# Altium schematic sheet 04_LCD.SchDoc
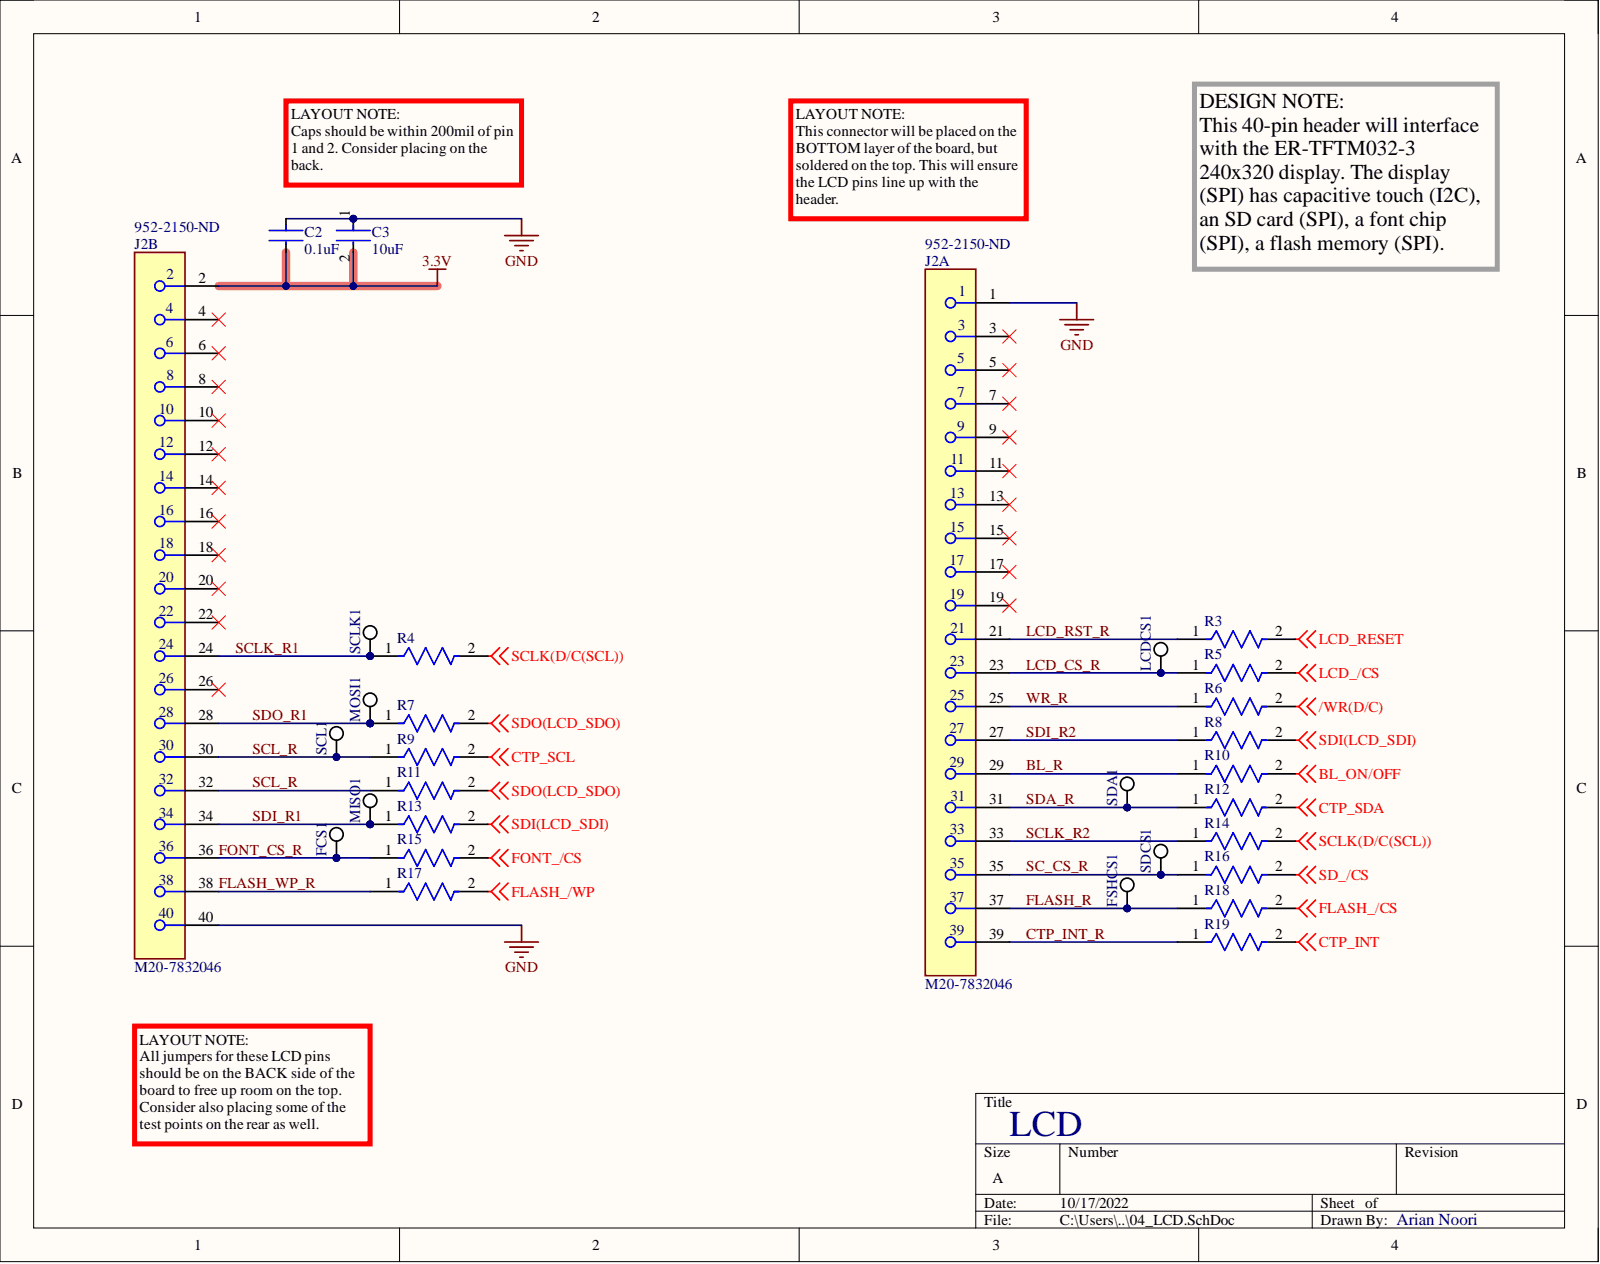
[diagram p0: 04_LCD.SchDoc]

## Parts
C2  0.1uF  [FP-CL21-IPC_C]
C3  value 10uF  Capacitance: 10uF  [FP-C0805C-DG-MFG]  (hidden comment: C0805C106K8PACTU)
FCS1  5019  [KSTN-5019_V]  (value not drawn)
FSHCS1  5019  [KSTN-5019_V]  (value not drawn)
J2  M20-7832046  Supplier Part Number 1: 952-2150-ND  [FP-M20-7832046-MFG]  (parts A,B)
LCDCS1  5019  [KSTN-5019_V]  (value not drawn)
MISO1  5019  [KSTN-5019_V]  (value not drawn)
MOSI1  5019  [KSTN-5019_V]  (value not drawn)
R3  (no value)  [FP-RC0805-MFG]
R4  (no value)  [FP-RC0805-MFG]
R5  (no value)  [FP-RC0805-MFG]
R6  (no value)  [FP-RC0805-MFG]
R7  (no value)  [FP-RC0805-MFG]
R8  (no value)  [FP-RC0805-MFG]
R9  (no value)  [FP-RC0805-MFG]
R10  (no value)  [FP-RC0805-MFG]
R11  (no value)  [FP-RC0805-MFG]
R12  (no value)  [FP-RC0805-MFG]
R13  (no value)  [FP-RC0805-MFG]
R14  (no value)  [FP-RC0805-MFG]
R15  (no value)  [FP-RC0805-MFG]
R16  (no value)  [FP-RC0805-MFG]
R17  (no value)  [FP-RC0805-MFG]
R18  (no value)  [FP-RC0805-MFG]
R19  (no value)  [FP-RC0805-MFG]
SCL1  5019  [KSTN-5019_V]  (value not drawn)
SCLK1  5019  [KSTN-5019_V]  (value not drawn)
SDA1  5019  [KSTN-5019_V]  (value not drawn)
SDCS1  5019  [KSTN-5019_V]  (value not drawn)

## Nets
3.3V: C2.2 C3.2 J2.2
/WR(D/C): R6.2
BL_ON/OFF: R10.2
CTP_INT: R19.2
CTP_SCL: R9.2
CTP_SDA: R12.2
FLASH_/CS: R18.2
FLASH_/WP: R17.2
FONT_/CS: R15.2
GND: C2.1 C3.1 J2.1 J2.40
LCD_/CS: R5.2
LCD_RESET: R3.2
SCLK(D/C(SCL)): R4.2 R14.2
SD_/CS: R16.2
SDI(LCD_SDI): R8.2 R13.2
SDO(LCD_SDO): R7.2 R11.2
BL_R: J2.29 R10.1
CTP_INT_R: J2.39 R19.1
FLASH_R: FSHCS1.1 J2.37 R18.1
FLASH_WP_R: J2.38 R17.1
FONT_CS_R: FCS1.1 J2.36 R15.1
LCD_CS_R: J2.23 LCDCS1.1 R5.1
LCD_RST_R: J2.21 R3.1
SC_CS_R: J2.35 R16.1 SDCS1.1
SCL_R: J2.30 J2.32 R9.1 R11.1 SCL1.1
SCLK_R1: J2.24 R4.1 SCLK1.1
SCLK_R2: J2.33 R14.1
SDA_R: J2.31 R12.1 SDA1.1
SDI_R1: J2.34 MISO1.1 R13.1
SDI_R2: J2.27 R8.1
SDO_R1: J2.28 MOSI1.1 R7.1
WR_R: J2.25 R6.1

Not connected: J2 3 4 5 6 7 8 9 10 11 12 13 14 15 16 17 18 19 20 22 26

## Library data of the parts
C2 = CMP-2000-05017-2: CL21 Series 0805 100nF 50V ±10% Tolerance X7R Multilayer Ceramic Chip Capacitor; Manufacturer: Samsung; Manufacturer Part Number: CL21B104KBCNNNC; Capacitance: 100nF; Case Code (Imperial): 0805; Case Code (Metric): 2012; Case/Package: 0805; Category: Ceramic Capacitors; Component Kind: Standard; ComponentLink1Description: https://datasheet.ciiva.com/25710/cl21b104kbcnnnc-25710182.pdf?src-supplier=Digi-Key; ComponentLink1URL: https://datasheet.ciiva.com/25710/cl21b104kbcnnnc-25710182.pdf?src-supplier=Digi-Key; ComponentLink2Description: https://datasheet.ciiva.com/29199/454152-da-01-en-vs-kondensator-0805-29199814.pdf?src-supplier=Conrad; ComponentLink2URL: https://datasheet.ciiva.com/29199/454152-da-01-en-vs-kondensator-0805-29199814.pdf?src-supplier=Conrad; Construction: Flat; Contact Plating: Tin; Depth: 1.25mm; Dielectric: X7R; Height: 0.85mm; Lead Free: Lead Free; Length: 2mm; Library Name: Altium Content Vault; Material: Ceramic; Max Operating Temperature: 125°C; Min Operating Temperature: -55°C; Mount: Surface Mount; Packaging: Tape and Reel; Pricing 1: 1=0.10000, 10=0.04400, 50=0.02400, 100=0.01960, 500=0.01404, 1000=0.01103 (USD); Radiation Hardening: No; RoHS: Yes; RoHS Compliant: Yes; Series: CL; Stock 1: 4599648; Supplier 1: Digi-Key; Thickness: 0.037inch; Tolerance: 10%; Voltage: 50V; Voltage Rating: 50V; Voltage Rating (DC): 50V; Width: 0.049inch
C3 = CMP-2007-03243-2: CAP CER 10UF 10V X5R 0805; 3DS Part Number: 220-00001; Manufacturer: Kemet; Dielectric Material: Ceramic; Dissipation Factor: 10%; Temperature Coefficient: 15%; Automotive: No; Case Code (Imperial): 0805; Case Code (Metric): 2012; Case/Package: 0805; Category: Cap; Component Kind: Standard; ComponentLink2Description: Datasheet; ComponentLink2URL: https://content.kemet.com/datasheets/KEM_C1006_X5R_SMD.pdf; Construction: Flat; Contact Plating: Tin,Matte; Depth: 1.25mm; Device Class L1: Passive Components; Device Class L2: Capacitors; Device Class L3: Ceramic Capacitors; Dielectric: X5R; Digikey Description: CAP CER 10UF 10V X5R 0805; Height: 1.4mm; Ipc Land Pattern Name: CAPC200125X125; Lead Free: Yes; Length: 2mm; Library Name: Altium Content Vault; Manufacturer 1: KEMET; Manufacturer Part Number: C0805C106K8PACTU; Manufacturer Part Number 1: C0805C106K8PACTU; Material: Ceramic; Max Operating Temperature: 85°C; Min Operating Temperature: -55°C; Mount: Surface Mount; Mouser Description: CAP CER 10UF 10V X5R 0805; Number of Pins: 2; Octopart: https://octopart.com/c0805c106k8pactu-kemet-98697; Package: C0805C-DG; Package Quantity: 1; Packaging: Tape and Reel; Pricing 1: 1=0.14000, 10=0.10300, 50=0.05840, 100=0.04830, 500=0.03388, 1000=0.02805 (USD); Radiation Hardening: No; REACH SVHC: No SVHC; RoHS: TRUE; RoHS Compliant: Yes; Series: C; Stock 1: 8631846; Supplier 1: Digi-Key; Supplier Part Number 1: 399-C0805C106K8PAC7800CT-ND; Temperature: -55°C to +85°C; Temperature Characteristic: X5R; Temperature Range High: +85°C; Temperature Range Low: -55°C; Termination: SMD/SMT; Thickness: 0.055inch; Tolerance: 10%; Voltage: 10V; Voltage Rating: 10V; Voltage Rating (DC): 10V; Width: 1.2mm
FCS1 FSHCS1 LCDCS1 MISO1 MOSI1 SCL1 SCLK1 SDA1 SDCS1 = CMP-1672-00008-1: Test Point, 1 Position SMD, RoHS, Tape and Reel; Manufacturer: Keystone Electronics; Category: Connectors; Component Kind: Standard; ComponentLink1Description: Manufacturer URL; ComponentLink1URL: http://www.keyelco.com/; ComponentLink2Description: Datasheet; ComponentLink2URL: http://www.keyelco.com/product-pdf.cfm?p=1357; ComponentLink3Description: https://datasheet.ciiva.com/16318/m60-2p61-16318395.pdf?src-supplier=Future+Electronics; ComponentLink3URL: https://datasheet.ciiva.com/16318/m60-2p61-16318395.pdf?src-supplier=Future+Electronics; ComponentLink4Description: https://datasheet.ciiva.com/16885/70182998-16885945.pdf?src-supplier=Allied+Electronics; ComponentLink4URL: https://datasheet.ciiva.com/16885/70182998-16885945.pdf?src-supplier=Allied+Electronics; ComponentLink5Description: https://datasheet.ciiva.com/14848/m60-2p61-14848437.pdf?src-supplier=Digi-Key; ComponentLink5URL: https://datasheet.ciiva.com/14848/m60-2p61-14848437.pdf?src-supplier=Digi-Key; ComponentLink6Description: https://datasheet.ciiva.com/14848/m60-2p61-14848438.pdf?src-supplier=Digi-Key; ComponentLink6URL: https://datasheet.ciiva.com/14848/m60-2p61-14848438.pdf?src-supplier=Digi-Key; ComponentLink7Description: https://datasheet.ciiva.com/14848/m60-2p61-14848439.pdf?src-supplier=Digi-Key; ComponentLink7URL: https://datasheet.ciiva.com/14848/m60-2p61-14848439.pdf?src-supplier=Digi-Key; Contact Material: Bronze; Contact Plating: Silver; DatasheetVersion: 03/2014; Height: 0.09inch; Lead Free: Lead Free; Library Name: Altium Content Vault; Manufacturer Part Number: 5019; Material: Bronze; Mount: Surface Mount; Mounting Technology: Surface Mount; Number of Pins: 1; Package Quantity: 1000; PackageDescription: 1-Pin Surface Mount Device, Body 3.81 x 2.03 mm; PackageReference: 5019; Packaging: Tape and Reel; Pins: 1; Pricing 1: 1=0.34000, 25=0.27360, 100=0.22340, 250=0.20064, 500=0.19152 (USD); REACH SVHC: No SVHC; RoHS: Yes; RoHS Compliant: Yes; RoHSCompliant: True; Series: 5019; Stock 1: 1295398; Supplier 1: Digi-Key; Termination: SMD/SMT
J2 = CMP-15831-000036-1: CONN RCPT 40POS 0.1 TIN PCB; Manufacturer: Harwin; Connector Type: Receptacle; Contact Resistance: 20mR; Current Rating: 3A; Hole Diameter: 0.8mm; Insulation Resistance: 1MR; Mating Cycles: 50; Number of Contacts: 40; Pitch: 2.54mm; Row Spacing: 0.1inch; Category: Headers and Wire Housings; Color: Black; Component Kind: Standard; ComponentLink1Description: https://datasheet.ciiva.com/11748/0900766b80dc1d2a-11748805.pdf?src-supplier=RSComponents; ComponentLink1URL: https://datasheet.ciiva.com/11748/0900766b80dc1d2a-11748805.pdf?src-supplier=RSComponents; ComponentLink2Description: https://datasheet.ciiva.com/26852/getdatasheetpartid-499542-26852174.pdf?src-supplier=Verical; ComponentLink2URL: https://datasheet.ciiva.com/26852/getdatasheetpartid-499542-26852174.pdf?src-supplier=Verical; ComponentLink3Description: https://datasheet.ciiva.com/9479/m20-783-9479864.pdf?src-supplier=Avnet; ComponentLink3URL: https://datasheet.ciiva.com/9479/m20-783-9479864.pdf?src-supplier=Avnet; ComponentLink4Description: https://datasheet.ciiva.com/6795/m20-783-6795342.pdf?src-supplier=Digi-Key; ComponentLink4URL: https://datasheet.ciiva.com/6795/m20-783-6795342.pdf?src-supplier=Digi-Key; ComponentLink5Description: https://datasheet.ciiva.com/7639/1836950-7639737.pdf?src-supplier=Element14; ComponentLink5URL: https://datasheet.ciiva.com/7639/1836950-7639737.pdf?src-supplier=Element14; Contact Gender: Female; Contact Material: Bronze; Contact Plating: Tin; Contact Type: Female Socket; Depth: 5.04mm; Gender: Receptacle; Housing Color: Black; Housing Material: Polyamide; Lead Pitch: 2.54mm; Length: 51.2mm; Library Name: Altium Content Vault; Manufacturer Part Number: M20-7832046; Material: Glass; Max Current Rating: 3A; Max Operating Temperature: 105°C; Min Operating Temperature: -40°C; Mount: Through Hole; Number of Positions: 40; Number of Rows: 2; Orientation: Straight; Package Quantity: 11; Packaging: Rail/Tube; Pricing 1: 1=3.77000, 11=3.42273, 88=3.01591, 440=2.56348, 946=2.18650, 4906=1.96031 (USD); Radiation Hardening: No; REACH SVHC: No SVHC; RoHS: Yes; RoHS Compliant: Yes; Series: M20; Stock 1: 6647; Supplier 1: Digi-Key; Termination: Solder
R3-R19 = CMP-2001-04570-2: RES SMD 0 OHM JUMPER 1/8W 0805; Manufacturer: Yageo; Manufacturer Part Number: RC0805FR-070RL; Max Power Dissipation: 125mW; Power Rating: 0.125W; Resistance: 0Ω; Tolerance: 1%; Value: 0Ω; Voltage Rating: 150V; Automotive: No; Case Code (Imperial): 0805; Case Code (Metric): 2012; Case/Package: 0805; Category: Res; Component Kind: Standard; ComponentLink1Description: https://datasheet.ciiva.com/15189/getdatasheetpartid-348154-15189885.pdf?src-supplier=Verical; ComponentLink1URL: https://datasheet.ciiva.com/15189/getdatasheetpartid-348154-15189885.pdf?src-supplier=Verical; ComponentLink2Description: Datasheet; ComponentLink2URL: http://www.yageo.com/documents/recent/PYu-RC_Group_51_RoHS_L_10.pdf; ComponentLink3Description: https://datasheet.ciiva.com/17042/rc0805-17042698.pdf?src-supplier=Future+Electronics; ComponentLink3URL: https://datasheet.ciiva.com/17042/rc0805-17042698.pdf?src-supplier=Future+Electronics; Composition: Thick Film; Construction: Rectangular; Contact Plating: Tin,Matte; Depth: 1.25mm; Device Class L1: Passive Components; Device Class L2: Resistors; Device Class L3: Chip SMD Resistors; Digikey Description: RES SMD 0 OHM JUMPER 1/8W 0805; Features: Moisture Resistant; Footprint Url: http://www.yageo.com/exep/pages/download/literatures/PYu-R_Mount_10.pdf; Height: 0.6mm; Ipc Land Pattern Name: RESC200125X50; Lead Free: Yes; Length: 2mm; Library Name: Altium Content Vault; Max Operating Temperature: 155°C; Military Standard: Not; Min Operating Temperature: -55°C; Number of Terminations: 2; Octopart: https://octopart.com/rc0805fr-070rl-yageo-9293404; Package: RC0805; Packaging: Tape and Reel; Pricing 1: 1=0.10000, 10=0.03300, 25=0.02360, 50=0.01800, 100=0.01330, 250=0.01012, 500=0.00810, 1000=0.00597 (USD); Radiation Hardening: No; Resistor Type: Thick Film; RoHS: TRUE; RoHS Compliant: Yes; Series: RC_L; Size Code: 0805; Stock 1: 908367; Supplier 1: Digi-Key; Temperature: -55°C to +155°C; Temperature Range High: +155°C; Temperature Range Low: -55°C
